# Altium schematic sheet MAIN.SchDoc(MAIN)
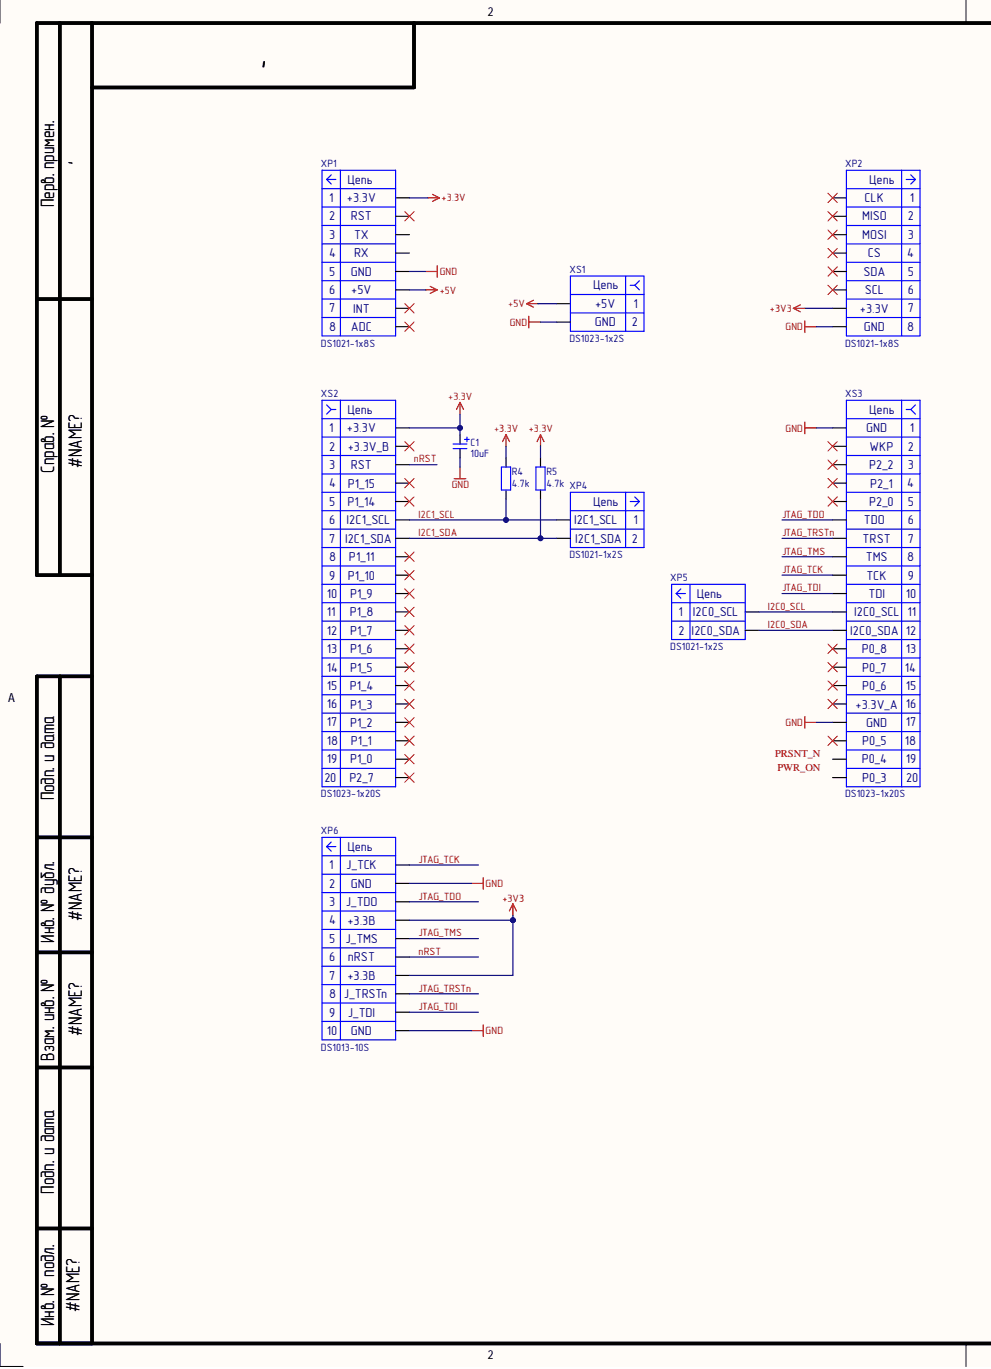
[diagram p0: MAIN.SchDoc, left]
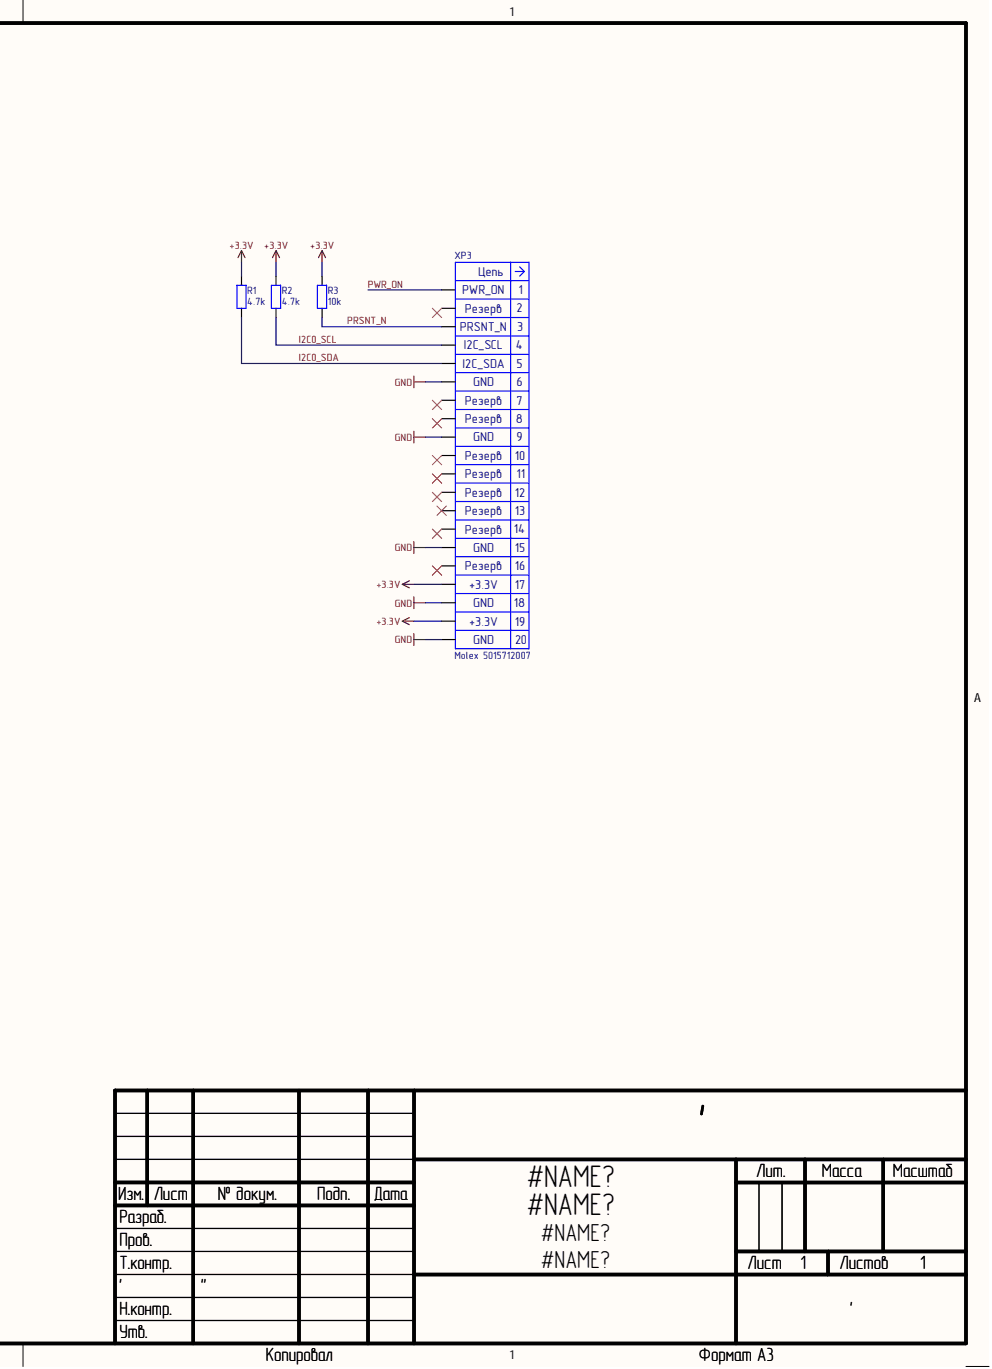
[diagram p1: MAIN.SchDoc, right]

## Parts
C1  10uF  [CAP ALSMD ELEC 4.3MM H5.4MM]
R1  4.7k  [RES 0603_1608]
R2  4.7k  [RES 0603_1608]
R3  10k  [RES 0603_1608]
R4  4.7k  [RES 0603_1608]
R5  4.7k  [RES 0603_1608]
XP1  DS1021-1x8S  Net_1: +3.3V  Net_2: RST  Net_3: TX  Net_4: RX  Net_5: GND  Net_6: +5V  Net_7: INT  Net_8: ADC  [DS1021-1x8S]
XP2  DS1021-1x8S  Net_1: CLK  Net_2: MISO  Net_3: MOSI  Net_4: CS  Net_5: SDA  Net_6: SCL  Net_7: +3.3V  Net_8: GND  [DS1021-1x8S]
XP3  Molex 5015712007  Manufacturer: Molex  Net_1: PWR_ON  Net_2: Резерв  Net_3: PRSNT_N  Net_4: I2C_SCL  Net_5: I2C_SDA  Net_6: GND  Net_17: +3.3V  [Molex 5015712007]
XP4  DS1021-1x2S  Net_1: I2C1_SCL  Net_2: I2C1_SDA  [DS1021-1x2S]
XP5  DS1021-1x2S  Net_1: I2C0_SCL  Net_2: I2C0_SDA  [DS1021-1x2S]
XP6  DS1013-10S  Net_1: J_TCK  Net_2: GND  Net_3: J_TDO  Net_4: +3.3В  Net_5: J_TMS  Net_6: nRST  Net_8: J_TRSTn  Net_9: J_TDI  [DS1013-10S]
XS1  DS1023-1x2S  Net_1: +5V  Net_2: GND  [DS1023-1x2S]
XS2  DS1023-1x20S  Net_1: +3.3V  Net_2: +3.3V_B  Net_3: RST  Net_4: P1_15  Net_5: P1_14  Net_6: I2C1_SCL  Net_7: I2C1_SDA  Net_8: P1_11  Net_9: P1_10  Net_10: P1_9  Net_11: P1_8  Net_12: P1_7  Net_13: P1_6  Net_14: P1_5  Net_15: P1_4  Net_16: P1_3  Net_17: P1_2  Net_18: P1_1  Net_19: P1_0  Net_20: P2_7  [DS1023-1x20S]
XS3  DS1023-1x20S  Net_1: GND  Net_2: WKP  Net_3: P2_2  Net_4: P2_1  Net_5: P2_0  Net_6: TDO  Net_7: TRST  Net_8: TMS  Net_9: TCK  Net_10: TDI  Net_11: I2C0_SCL  Net_12: I2C0_SDA  Net_13: P0_8  Net_14: P0_7  Net_15: P0_6  Net_16: +3.3V_A  Net_18: P0_5  Net_19: P0_4  Net_20: P0_3  [DS1023-1x20S]

## Nets
+3.3V: C1.1 R1.2 R2.2 R3.2 R4.2 R5.2 XP1.1(+3.3V) XP3.17(+3.3V) XP3.19(+3.3V) XS2.1(+3.3V)
+3V3: XP2.7(+3.3V) XP6.4(+3.3В) XP6.7(+3.3В)
+5V: XP1.6 XS1.1
GND: C1.2 XP1.5 XP2.8(GND) XP3.6(GND) XP3.9(GND) XP3.15(GND) XP3.18(GND) XP3.20(GND) XP6.2 XP6.10 XS1.2 XS3.1(GND) XS3.17(GND)
I2C0_SCL: R2.1 XP3.4(I2C_SCL) XP5.1 XS3.11(I2C0_SCL)
I2C0_SDA: R1.1 XP3.5(I2C_SDA) XP5.2 XS3.12(I2C0_SDA)
I2C1_SCL: R4.1 XP4.1 XS2.6(I2C1_SCL)
I2C1_SDA: R5.1 XP4.2 XS2.7(I2C1_SDA)
JTAG_TCK: XP6.1(J_TCK) XS3.9(TCK)
JTAG_TDI: XP6.9(J_TDI) XS3.10(TDI)
JTAG_TDO: XP6.3(J_TDO) XS3.6(TDO)
JTAG_TMS: XP6.5(J_TMS) XS3.8(TMS)
JTAG_TRSTn: XP6.8(J_TRSTn) XS3.7(TRST)
nRST: XP6.6(nRST) XS2.3
PRSNT_N: R3.1 XP3.3(PRSNT_N)
PWR_ON: XP3.1(PWR_ON)

Not connected: XP1 2 3 4 7 8; XP2 1(CLK) 2(MISO) 3(MOSI) 4(CS) 5(SDA) 6(SCL); XP3 2(Резерв) 7(Резерв) 8(Резерв) 10(Резерв) 11(Резерв) 12(Резерв) 13(Резерв) 14(Резерв) 16(Резерв); XS2 2(+3.3V_B) 4(P1_15) 5(P1_14) 8(P1_11) 9(P1_10) 10(P1_9) 11(P1_8) 12(P1_7) 13(P1_6) 14(P1_5) 15(P1_4) 16(P1_3) 17(P1_2) 18 19(P1_0) 20(P2_7); XS3 2(WKP) 3(P2_2) 4 5(P2_0) 13(P0_8) 14(P0_7) 15(P0_6) 16(+3.3V_A) 18(P0_5) 19(P0_4) 20(P0_3)

## Library data of the parts
C1 = К50-97 – 25В – 10мкФ (±20) % – ЕВАЯ.673541.054ТУ: Конденсатор К50-97 – 25В – 10мкФ (±20) % – ЕВАЯ.673541.054ТУ; Manufacturer: АО Элеконд; Database Table Name: capacitors.csv; Dielectric type: Electrolytic; Group: Конденсаторы полярные; Tolerance: ± 20%; Type: Конденсатор электролитический; Voltage: 25V; Component Kind: Standard; Country: Россия; HelpURL: https://elecond.ru/pdf/k50-97.pdf; Library Name: ComponentsDb.DbLib; Manufacturer Part Number: К50-97 – 25В – 10мкФ (±20) % – ЕВАЯ.673541.054ТУ; Name: К50-97 – 25В – 10мкФ (±20) % – ЕВАЯ.673541.054ТУ; Package: SMD D4x5.4; Value: 10uF
R1 R2 R4 R5 = RC0603FR-074K7L: Резистор 0603-0,1Вт-4.7кОм ± 1%; Manufacturer: Yageo; Database Table Name: resistors.csv; Group: Резисторы; Power: 0.1W; Voltage: 75V; Component Kind: Standard; Country: Тайвань; HelpURL: https://static.chipdip.ru/lib/175/DOC011175144.pdf; Library Name: ComponentsDb.DbLib; Manufacturer Part Number: RC0603FR-074K7L; Name: RC0603FR-074K7L; Package: SMD0603; Tolerance: ± 1%; Type: Резистор; Value: 4.7k
R3 = RC0603FR-0710KL: Резистор 0603-0,1Вт-10кОм ± 1%; Manufacturer: Yageo; Database Table Name: resistors.csv; Group: Резисторы; Power: 0.1W; Voltage: 75V; Component Kind: Standard; Country: Тайвань; HelpURL: https://static.chipdip.ru/lib/175/DOC011175144.pdf; Library Name: ComponentsDb.DbLib; Manufacturer Part Number: RC0603FR-0710KL; Name: RC0603FR-0710KL; Package: SMD0603; Tolerance: ± 1%; Type: Резистор; Value: 10k
XP1 XP2 = DS1021-1x8S: Соединитель DS1021-1x8S; Manufacturer: Connfly; Database Table Name: connectors.csv; Group: Соединители; Max Temperature: 105°С; Min Temperature: -40°С; Nominal current: 3A; Nominal Voltage: 250V; Pins number: 8; Rows: 1; Component Kind: Standard; Country: Китай; Library Name: ComponentsDb.DbLib; Manufacturer Part Number: DS1021-1x8S; Name: DS1021-1x8S; Type: Штыри прямые
XP3 = Molex 5015712007: Соединитель Molex 5015712007; Database Table Name: connectors.csv; Group: Соединители; Max Temperature: 105°С; Min Temperature: -40°С; Nominal current: 1A; Nominal Voltage: 50V; Pins number: 20; Rows: 2; Component Kind: Standard; Country: США; Library Name: ComponentsDb.DbLib; Manufacturer Part Number: Molex 5015712007; Name: Molex 5015712007; Net_7: Резерв; Net_8: Резерв; Net_9: GND; Net_10: Резерв; Net_11: Резерв; Net_12: Резерв; Net_13: Резерв; Net_14: Резерв; Net_15: GND; Net_16: Резерв; Net_18: GND; Net_19: +3.3V; Net_20: GND; Type: Вилка угловая
XP4 XP5 = DS1021-1x2S: Соединитель DS1021-1x2S; Manufacturer: Connfly; Database Table Name: connectors.csv; Group: Соединители; Max Temperature: 105°С; Min Temperature: -40°С; Nominal current: 3A; Nominal Voltage: 250V; Pins number: 2; Rows: 1; Component Kind: Standard; Country: Китай; Library Name: ComponentsDb.DbLib; Manufacturer Part Number: DS1021-1x2S; Name: DS1021-1x2S; Type: Штыри прямые
XP6 = DS1013-10S: Соединитель DS1013-10S; Manufacturer: Connfly; Database Table Name: connectors.csv; Group: Соединители; Max Temperature: 105°С; Min Temperature: -40°С; Nominal current: 1A; Nominal Voltage: 500V; Pins number: 10; Rows: 2; Component Kind: Standard; Country: Китай; Library Name: ComponentsDb.DbLib; Manufacturer Part Number: DS1013-10S; Name: DS1013-10S; Net_7: +3.3В; Net_10: GND; Type: Вилка прямая
XS1 = DS1023-1x2S: Соединитель DS1023-1x2S; Manufacturer: Connfly; Database Table Name: connectors.csv; Group: Соединители; Max Temperature: 105°С; Min Temperature: -40°С; Nominal current: 1A; Nominal Voltage: 250V; Pins number: 2; Rows: 1; Component Kind: Standard; Country: Китай; Library Name: ComponentsDb.DbLib; Manufacturer Part Number: DS1023-1x2S; Name: DS1023-1x2S; Type: Гнездо на плату
XS2 XS3 = DS1023-1x20S: Соединитель DS1023-1x20S; Manufacturer: Connfly; Database Table Name: connectors.csv; Group: Соединители; Max Temperature: 105°С; Min Temperature: -40°С; Nominal current: 1A; Nominal Voltage: 250V; Pins number: 20; Rows: 1; Component Kind: Standard; Country: Китай; Library Name: ComponentsDb.DbLib; Manufacturer Part Number: DS1023-1x20S; Name: DS1023-1x20S; Type: Гнездо на плату
  XS3: Net_17: GND
